# Altium schematic sheet Duy.SchDoc
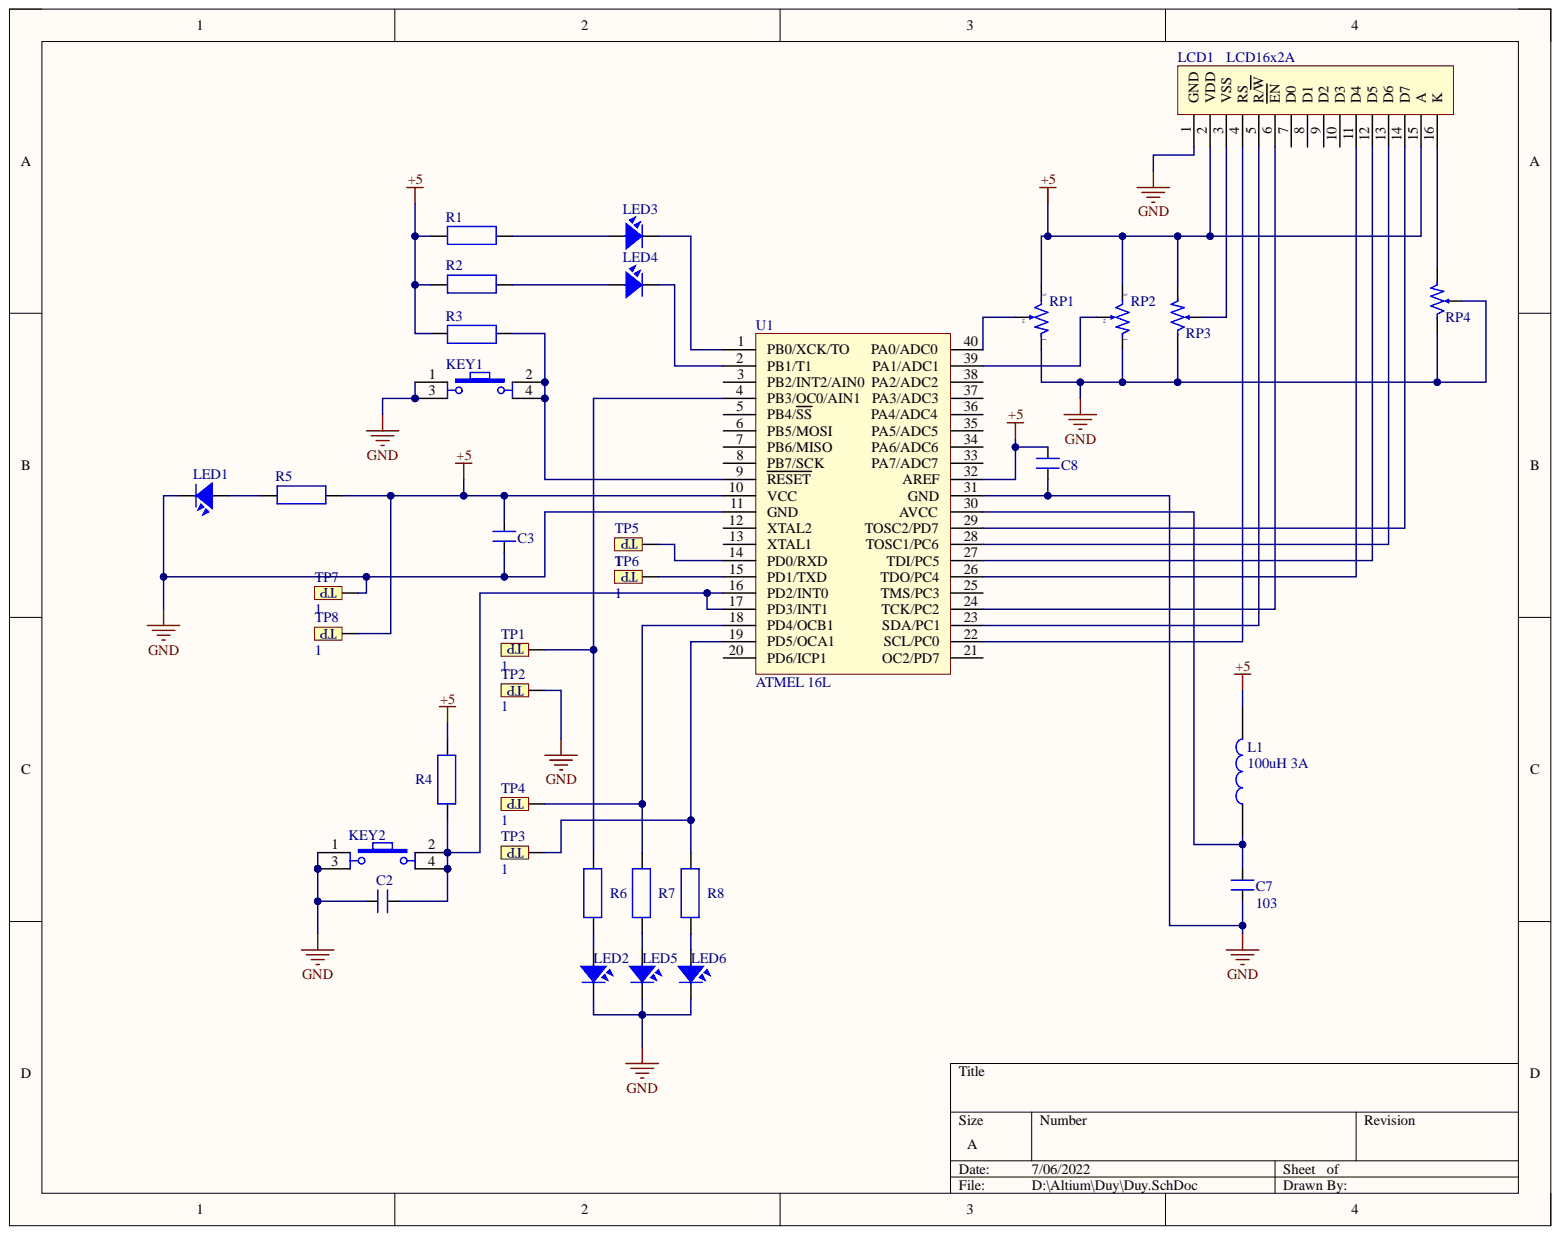
[diagram p0: Duy.SchDoc]

## Parts
C2  (no value)  [CN1.5]
C3  (no value)  [CN1.5]
C7  103  [CN1.5]
C8  (no value)  [CN1.5]
KEY1  (no value)  [SW4]
KEY2  (no value)  [SW4]
L1  100uH 3A  [CUON CAM 100uH3A]
LCD1  LCD16x2A  [LCD16X2]
LED1  (no value)  [LED-3]
LED2  (no value)  [LED-3]
LED3  (no value)  [LED-3]
LED4  (no value)  [LED-3]
LED5  (no value)  [LED-3]
LED6  (no value)  [LED-3]
R1  (no value)  [R1W]
R2  (no value)  [R1W]
R3  (no value)  [R1W]
R4  (no value)  [R1W]
R5  (no value)  [R1W]
R6  (no value)  [R1W]
R7  (no value)  [R1W]
R8  (no value)  [R1W]
RP1  (no value)  [VOL-S]
RP2  (no value)  [VOL-S]
RP3  (no value)  [VR]
RP4  (no value)  [VR]
TP1  1  [TP]
TP2  1  [TP]
TP3  1  [TP]
TP4  1  [TP]
TP5  1  [TP]
TP6  1  [TP]
TP7  1  [TP]
TP8  1  [TP]
U1  ATMEL 16L  [DIP40/W0.6]

## Nets
+5: C3.2 C8.2 L1.2 LCD1.2(VDD) LCD1.15(A) R1.1 R2.1 R3.2 R4.2 R5.2 RP1.3 RP2.3 RP3.1 TP8.TP1 U1.10(VCC) U1.32(AREF)
GND: C2.2 C3.1 C7.2 C8.1 KEY1.1 KEY1.3 KEY2.1 KEY2.3 LCD1.1(GND) LED1.2 LED2.2 LED5.2 LED6.2 RP1.1 RP2.1 RP3.3 RP4.2 RP4.3 TP2.TP1 TP7.TP1 U1.11(GND) U1.31(GND)
NetC2_1: C2.1 KEY2.2 KEY2.4 R4.1 U1.16(PD2/INT0) U1.17(PD3/INT1)
NetC7_1: C7.1 L1.1 U1.30(AVCC)
NetKEY1_2: KEY1.2 KEY1.4 R3.1 U1.9(RESET)
NetLCD1_3: LCD1.3(VSS) RP3.2
NetLCD1_4: LCD1.4(RS) U1.22(SCL/PC0)
NetLCD1_5: LCD1.5(R/W) U1.23(SDA/PC1)
NetLCD1_6: LCD1.6(EN) U1.24(TCK/PC2)
NetLCD1_11: LCD1.11(D4) U1.26(TDO/PC4)
NetLCD1_12: LCD1.12(D5) U1.27(TDI/PC5)
NetLCD1_13: LCD1.13(D6) U1.28(TOSC1/PC6)
NetLCD1_14: LCD1.14(D7) U1.29(TOSC2/PD7)
NetLCD1_16: LCD1.16(K) RP4.1
NetLED1_1: LED1.1 R5.1
NetLED2_1: LED2.1 R6.1
NetLED3_1: LED3.1 R1.2
NetLED3_2: LED3.2 U1.1(PB0/XCK/TO)
NetLED4_1: LED4.1 R2.2
NetLED4_2: LED4.2 U1.2(PB1/T1)
NetLED5_1: LED5.1 R7.1
NetLED6_1: LED6.1 R8.1
NetR6_2: R6.2 TP1.TP1 U1.4(PB3/OC0/AIN1)
NetR7_2: R7.2 TP4.TP1 U1.18(PD4/OCB1)
NetR8_2: R8.2 TP3.TP1 U1.19(PD5/OCA1)
NetRP1_2: RP1.2 U1.40(PA0/ADC0)
NetRP2_2: RP2.2 U1.39(PA1/ADC1)
NetTP5_TP1: TP5.TP1 U1.14(PD0/RXD)
NetTP6_TP1: TP6.TP1 U1.15(PD1/TXD)

Not connected: LCD1 7(D0) 8(D1) 9(D2) 10(D3); U1 3(PB2/INT2/AIN0) 5(PB4/SS) 6(PB5/MOSI) 7(PB6/MISO) 8(PB7/SCK) 12(XTAL2) 13(XTAL1) 20(PD6/ICP1) 21(OC2/PD7) 25(TMS/PC3) 33(PA7/ADC7) 34(PA6/ADC6) 35(PA5/ADC5) 36(PA4/ADC4) 37(PA3/ADC3) 38(PA2/ADC2)

## Library data of the parts
C2 C3 C7 C8 = CN1.5: Cap np 682pF => 104pF; Value: 0.01uF; Component Kind: Standard; Library Name: syhaunguyen.SchLib
KEY1 KEY2 = SW4: Switch button 4 pin; Value: Button chip 4 pin; Component Kind: Standard; Library Name: syhaunguyen.SchLib
L1 = CUON CAM 100uH DIP: Dán; Don_Vi: Con; Ma_Hang: MH002209; Ten_Linh_Kien: Cuộn Cảm 100uH 3A 8x10MM; Chung_Loai: Dán; Component Kind: Standard; Library Name: Altium_Lib_by_KhueNguyenCreator.IntLib
LCD1 = LCD16x2: LCD dipslay 16 char, 2 line; Component Kind: Standard; Value: LCD16x2A; Library Name: syhaunguyen.SchLib
LED1-LED6 = LED: LED Single colour Green and Red; Value: LED 3 ly Single Colour; Component Kind: Standard; Library Name: syhaunguyen.SchLib
R1-R8 = R1W: Resistor 1W; Value: R1W - 100; Component Kind: Standard; Library Name: syhaunguyen.SchLib
RP1 RP2 = VOL-S: Var Resistor; Value: Volume 50k; Component Kind: Standard; Library Name: syhaunguyen.SchLib
RP3 RP4 = VR1: Var Resistor; Value: VR 10K; Component Kind: Standard; Library Name: syhaunguyen.SchLib
TP1-TP8 = TP: CONNECT 1; Component Kind: Standard; Library Name: syhaunguyen.SchLib
U1 = Atmega16L: 8-Bit AVR Microcontroller with 16K Bytes of In-System Programmable Flash Memory; Component Kind: Standard; Value: Atmega 16L; Library Name: syhaunguyen.SchLib
